# Altium schematic sheet 18 4G&5G.SchDoc
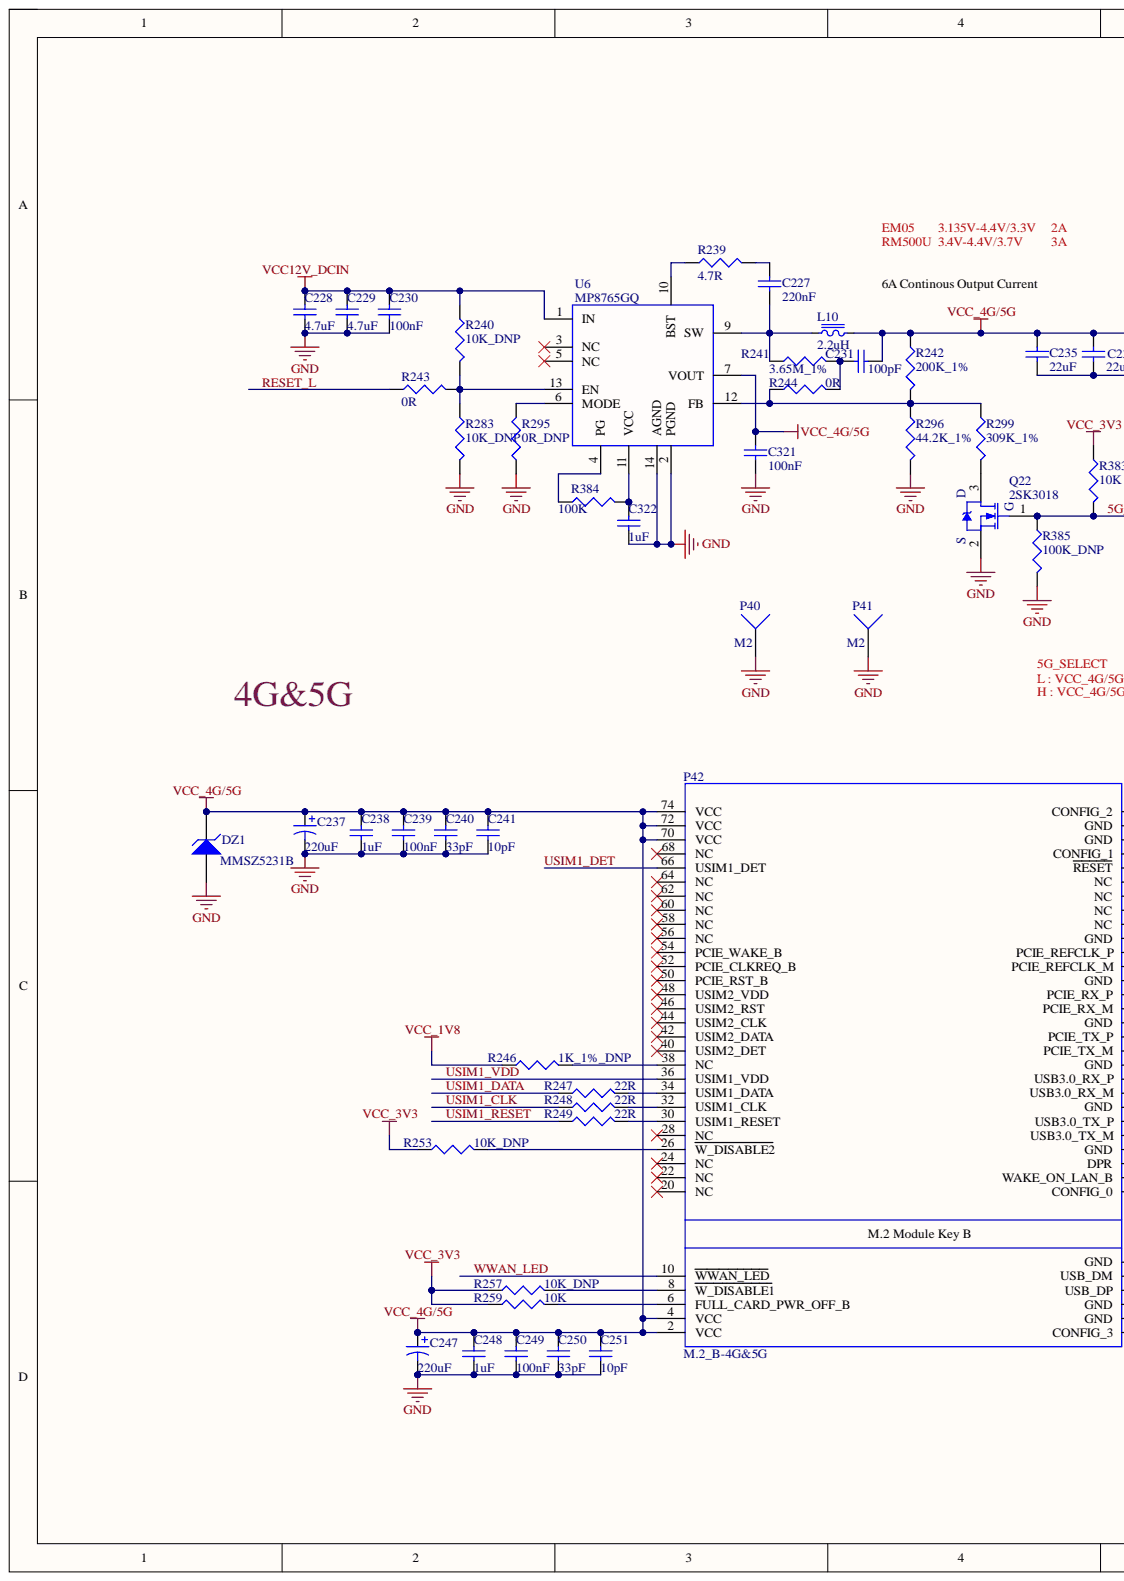
[diagram p0: 18 4G&5G.SchDoc, left]
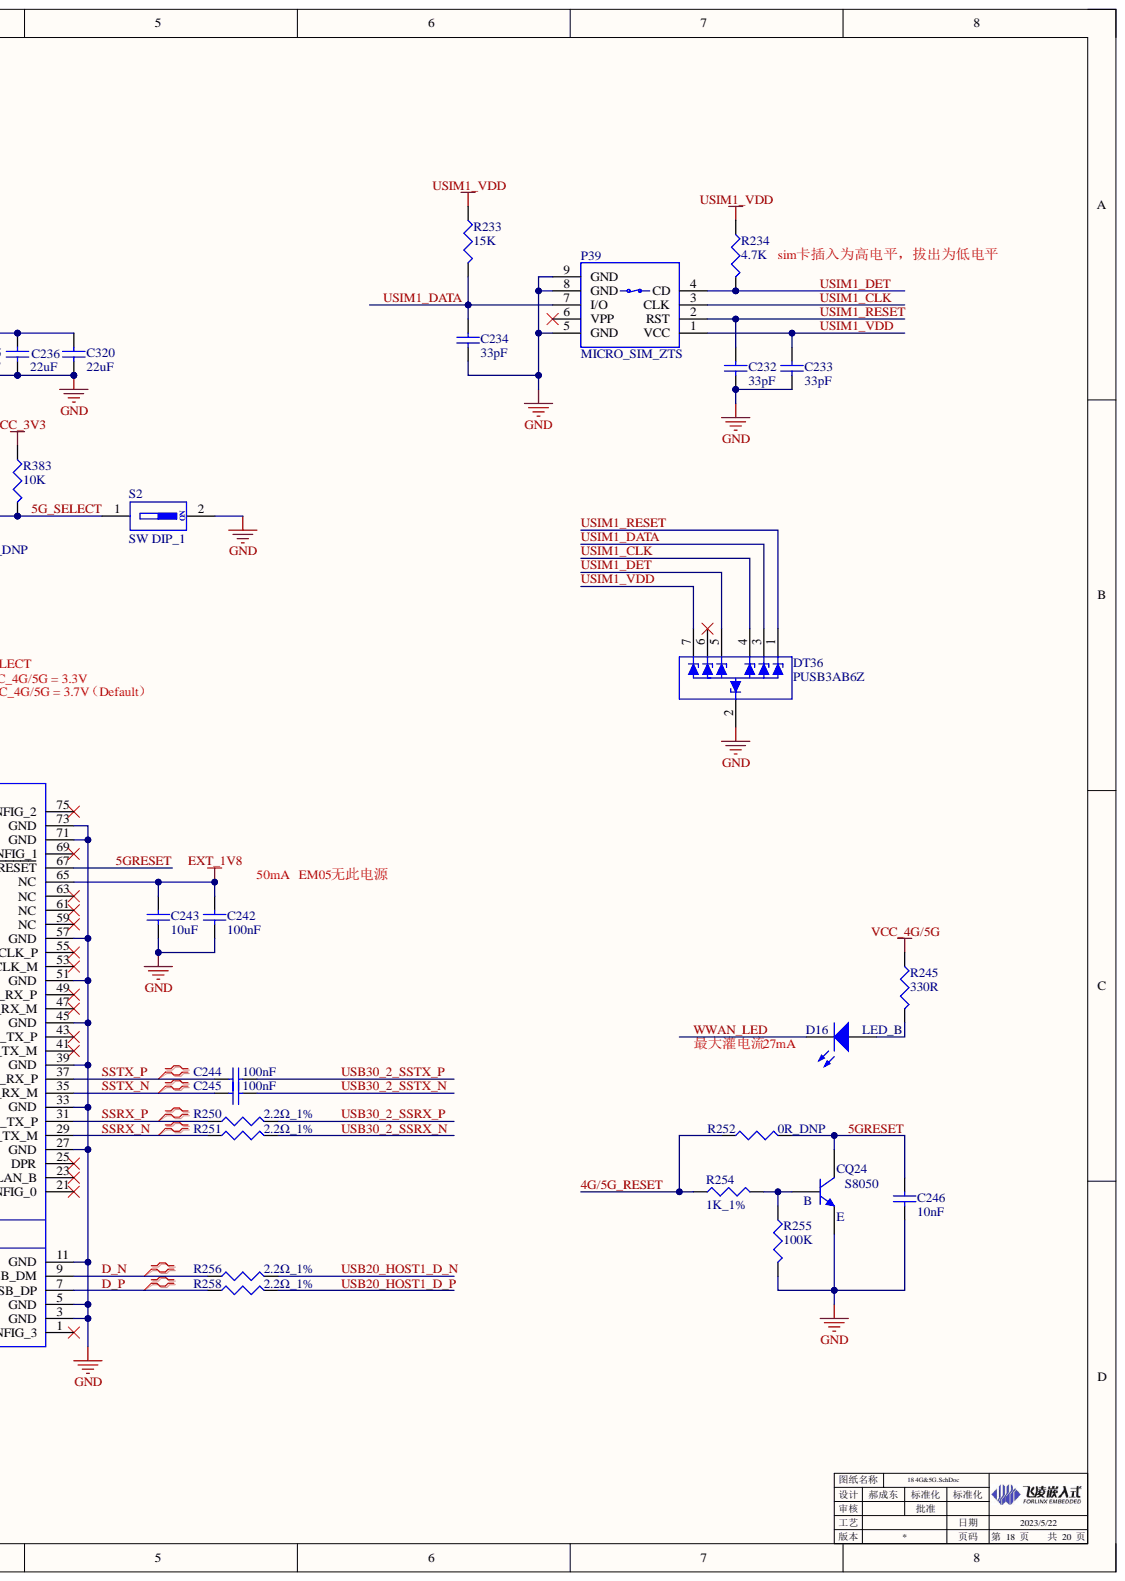
[diagram p1: 18 4G&5G.SchDoc, right]

## Parts
C227  220nF  [0402C]
C228  4.7uF  [0805C]
C229  4.7uF  [0805C]
C230  100nF  [0402C]
C231  100pF  [0402C]
C232  33pF  [0402C]
C233  33pF  [0402C]
C234  33pF  [0402C]
C235  22uF  [0805C]
C236  22uF  [0805C]
C237  220uF  [CAP SMT_6.3x7.7]
C238  1uF  [0402C]
C239  100nF  [0402C]
C240  33pF  [0402C]
C241  10pF  [0402C]
C242  100nF  [0402C]
C243  10uF  [0402C]
C244  100nF  [0402C]
C245  100nF  [0402C]
C246  10nF  [0402C]
C247  220uF  [CAP SMT_6.3x7.7]
C248  1uF  [0402C]
C249  100nF  [0402C]
C250  33pF  [0402C]
C251  10pF  [0402C]
C320  22uF  [0805C]
C321  100nF  [0402C]
C322  1uF  [0402C]
D16  LED_B  [0603LED_B]
DT36  PUSB3AB6Z  [DFN2111-7]
DZ1  MMSZ5231B  [SOD_123]
L10  2.2uH  [WPN4020H]
P39  MICRO_SIM_ZTS  [MICRO_SIM_ZTS]
P40  M2  [HOLE3.6-5.6]
P41  M2  [HOLE3.6-5.6]
P42  M.2_B-4G&5G  [M.2_B_4G5G]
Q22  2SK3018  [SOT323]
Q24  S8050  [SOT_233_N]
R233  15K  [0402R]
R234  4.7K  [0402R]
R239  4.7R  [0603R]
R240  10K_DNP  [0402R]
R241  3.65M_1%  [0603R]
R242  200K_1%  [0402R]
R243  0R  [0402R]
R244  0R  [0402R]
R245  330R  [0402R]
R246  1K_1%_DNP  [0402R]
R247  22R  [0402R]
R248  22R  [0402R]
R249  22R  [0402R]
R250  2.2Ω_1%  [0402R]
R251  2.2Ω_1%  [0402R]
R252  0R_DNP  [0402R]
R253  10K_DNP  [0402R]
R254  1K_1%  [0402R]
R255  100K  [0402R]
R256  2.2Ω_1%  [0402R]
R257  10K_DNP  [0402R]
R258  2.2Ω_1%  [0402R]
R259  10K  [0402R]
R283  10K_DNP  [0402R]
R295  0R_DNP  [0402R]
R296  44.2K_1%  [0402R]
R299  309K_1%  [0402R]
R383  10K  [0402R]
R384  100K  [0402R]
R385  100K_DNP  [0402R]
S2  SW DIP_1  [SWITCH_1P_DIP]
U6  MP8765GQ  [QFN16P_MP8765]

## Nets
EXT_1V8: C242.2 C243.2 P42.65(NC)
GND: C228.1 C229.1 C230.1 C232.1 C233.1 C234.1 C235.1 C236.1 C237.2 C238.1 C239.1 C240.1 C241.1 C242.1 C243.1 C246.1 C247.2 C248.1 C249.1 C250.1 C251.1 C320.1 C321.1 C322.1 DT36.2 DZ1.1 P39.5(GND) P39.8(GND) P39.9(GND) P40.1 P41.1 P42.3(GND) P42.5(GND) P42.11(GND) P42.27(GND) P42.33(GND) P42.39(GND) P42.45(GND) P42.51(GND) P42.57(GND) P42.71(GND) P42.73(GND) Q22.2 Q24.2 R255.2 R283.2 R295.1 R296.2 R385.2 S2.2 U6.2(PGND) U6.14(AGND)
VCC12V_DCIN: C228.2 C229.2 C230.2 R240.1 U6.1(IN)
VCC_1V8: R246.1
VCC_3V3: R253.1 R257.1 R259.1 R383.1
VCC_4G/5G: C231.2 C235.2 C236.2 C237.1 C238.2 C239.2 C240.2 C241.2 C247.1 C248.2 C249.2 C250.2 C251.2 C320.2 C321.2 DZ1.2 L10.2 P42.2(VCC) P42.4(VCC) P42.70(VCC) P42.72(VCC) P42.74(VCC) R242.1 R245.1 U6.7(VOUT)
4G/5G_RESET: R252.1 R254.1
5G_SELECT: Q22.1 R383.2 R385.1 S2.1
5GRESET: C246.2 P42.67(RESET) Q24.3 R252.2
D_N: P42.9(USB_DM) R256.1
D_P: P42.7(USB_DP) R258.1
RESET_L: R243.1
SSRX_N: P42.29(USB3.0_TX_M) R251.1
SSRX_P: P42.31(USB3.0_TX_P) R250.1
SSTX_N: C245.1 P42.35(USB3.0_RX_M)
SSTX_P: C244.1 P42.37(USB3.0_RX_P)
USB20_HOST1_D_N: R256.2
USB20_HOST1_D_P: R258.2
USB30_2_SSRX_N: R251.2
USB30_2_SSRX_P: R250.2
USB30_2_SSTX_N: C245.2
USB30_2_SSTX_P: C244.2
USIM1_CLK: DT36.4 P39.3(CLK) R248.1
USIM1_DATA: C234.2 DT36.3 P39.7(I/O) R233.2 R247.1
USIM1_DET: DT36.5 P39.4(CD) P42.66(USIM1_DET) R234.2
USIM1_RESET: C232.2 DT36.1 P39.2(RST) R249.1
USIM1_VDD: C233.2 DT36.7 P39.1(VCC) P42.36(USIM1_VDD) R233.1 R234.1
WWAN_LED: D16.2 P42.10(WWAN_LED)
NetC227_1: C227.1 L10.1 R241.1 U6.9(SW)
NetC227_2: C227.2 R239.2
NetC231_1: C231.1 R241.2 R244.2
NetC322_2: C322.2 R384.2 U6.11(VCC)
NetD16_1: D16.1 R245.2
NetP42_6: P42.6(FULL_CARD_PWR_OFF_B) R259.2
NetP42_8: P42.8(W_DISABLE1) R257.2
NetP42_26: P42.26(W_DISABLE2) R253.2
NetP42_30: P42.30(USIM1_RESET) R249.2
NetP42_32: P42.32(USIM1_CLK) R248.2
NetP42_34: P42.34(USIM1_DATA) R247.2
NetP42_38: P42.38(NC) R246.2
NetQ22_3: Q22.3 R299.2
NetQ24_1: Q24.1 R254.2 R255.1
NetR239_1: R239.1 U6.10(BST)
NetR240_2: R240.2 R243.2 R283.1 U6.13(EN)
NetR242_2: R242.2 R244.1 R296.1 R299.1 U6.12(FB)
NetR295_2: R295.2 U6.6(MODE)
NetR384_1: R384.1 U6.4(PG)

Not connected: DT36 6; P39 6(VPP); P42 1(CONFIG_3) 20(NC) 21(CONFIG_0) 22(NC) 23(WAKE_ON_LAN_B) 24(NC) 25(DPR) 28(NC) 40(USIM2_DET) 41(PCIE_TX_M) 42(USIM2_DATA) 43(PCIE_TX_P) 44(USIM2_CLK) 46(USIM2_RST) 47(PCIE_RX_M) 48(USIM2_VDD) 49(PCIE_RX_P) 50(PCIE_RST_B) 52(PCIE_CLKREQ_B) 53(PCIE_REFCLK_M) 54(PCIE_WAKE_B) 55(PCIE_REFCLK_P) 56(NC) 58(NC) 59(NC) 60(NC) 61(NC) 62(NC) 63(NC) 64(NC) 68(NC) 69(CONFIG_1) 75(CONFIG_2); U6 3(NC) 5(NC)

## Library data of the parts
C227 = 1110202042: Database Table Name: VALID$; 存货名称: 贴装叠层陶瓷电容; 规格型号: 0402,220nF,25V,±10％,X5R; Component Kind: Standard; LatestRevisionDate: 17-Jul-2002; LatestRevisionNote: Re-released for DXP Platform.; Library Name: Database_AD Library.DbLib; PackageDescription: Radial Cap, Thru-Hole; 2 Leads; 0.3 in Pin Spacing; PackageReference: RAD-0.3; Published: 8-Jun-2000; Signal Integrity: Cap; Simulation: CAP; Value: 220nF; 存货编码: 1110202042; Publisher: Altium Limited
C228 C229 = 1110204006: Database Table Name: VALID$; 存货名称: 贴装叠层陶瓷电容; 序号: 738; 规格型号: 0805,4.7uF,50V,±10％,X5R; Component Kind: Standard; LatestRevisionDate: 17-Jul-2002; LatestRevisionNote: Re-released for DXP Platform.; Library Name: Database_AD Library.DbLib; PackageDescription: Radial Cap, Thru-Hole; 2 Leads; 0.3 in Pin Spacing; PackageReference: RAD-0.3; Published: 8-Jun-2000; Signal Integrity: Cap; Simulation: CAP; Value: 4.7uF; 存货编码: 1110204006; Publisher: Altium Limited
C230 C239 C249 C321 = 1110202039: Database Table Name: VALID$; 存货名称: 贴装叠层陶瓷电容; 序号: 626; 规格型号: 0402,100nF,25V,±10％,X5R; Component Kind: Standard; LatestRevisionDate: 17-Jul-2002; LatestRevisionNote: Re-released for DXP Platform.; Library Name: Database_AD Library.DbLib; PackageDescription: Radial Cap, Thru-Hole; 2 Leads; 0.3 in Pin Spacing; PackageReference: RAD-0.3; Published: 8-Jun-2000; Signal Integrity: Cap; Simulation: CAP; Value: 100nF; 存货编码: 1110202039; Publisher: Altium Limited
C231 = 1110202016: Database Table Name: VALID$; 存货名称: 贴装叠层陶瓷电容; 序号: 587; 规格型号: 0402,100pF,50V,±5％,NPO; Component Kind: Standard; LatestRevisionDate: 17-Jul-2002; LatestRevisionNote: Re-released for DXP Platform.; Library Name: Database_AD Library.DbLib; PackageDescription: Radial Cap, Thru-Hole; 2 Leads; 0.3 in Pin Spacing; PackageReference: RAD-0.3; Published: 8-Jun-2000; Signal Integrity: Cap; Simulation: CAP; Value: 100pF; 存货编码: 1110202016; Publisher: Altium Limited
C232-C234 C240 C250 = 1110202020: Database Table Name: VALID$; 存货名称: 贴装叠层陶瓷电容; 序号: 597; 规格型号: 0402,33pF,50V,±5％,NPO; Component Kind: Standard; LatestRevisionDate: 17-Jul-2002; LatestRevisionNote: Re-released for DXP Platform.; Library Name: Database_AD Library.DbLib; PackageDescription: Radial Cap, Thru-Hole; 2 Leads; 0.3 in Pin Spacing; PackageReference: RAD-0.3; Published: 8-Jun-2000; Signal Integrity: Cap; Simulation: CAP; Value: 33pF; 存货编码: 1110202020; Publisher: Altium Limited
C235 C236 C320 = 1110204014: Database Table Name: VALID$; 存货名称: 贴装叠层陶瓷电容; 安全库存: 2645; 序号: 751; 旧编码: 010203021; 旧规格型号: 0805 22uF 25V ±20％ X5R; 规格型号: 0805,22uF,25V,±20％,X5R; Component Kind: Standard; LatestRevisionDate: 17-Jul-2002; LatestRevisionNote: Re-released for DXP Platform.; Library Name: Database_AD Library.DbLib; PackageDescription: Radial Cap, Thru-Hole; 2 Leads; 0.3 in Pin Spacing; PackageReference: RAD-0.3; Published: 8-Jun-2000; Signal Integrity: Cap; Simulation: CAP; Value: 22uF; 存货编码: 1110204014; Publisher: Altium Limited
C237 C247 = 1110211005: Database Table Name: VALID$; 存货名称: 贴装铝电解电容; 存货编码: CS1C221M-CRE77; 序号: 861; 规格型号: 100uF,16V,6.3*5.9mm; Component Kind: Standard; LatestRevisionDate: 17-Jul-2002; LatestRevisionNote: Re-released for DXP Platform.; Library Name: Database_AD Library.DbLib; PackageDescription: Polarized Capacitor (Radial); 2 Leads; PackageReference: RB7.6-15; Published: 8-Jun-2000; Signal Integrity: Cap Pol; Simulation: CAP; Value: 220uF; Publisher: Altium Limited
C238 C248 C322 = 1110202021: Database Table Name: VALID$; 存货名称: 贴装叠层陶瓷电容; 序号: 600; 规格型号: 0402,1uF,16V,±10％,X5R; Component Kind: Standard; LatestRevisionDate: 17-Jul-2002; LatestRevisionNote: Re-released for DXP Platform.; Library Name: Database_AD Library.DbLib; PackageDescription: Radial Cap, Thru-Hole; 2 Leads; 0.3 in Pin Spacing; PackageReference: RAD-0.3; Published: 8-Jun-2000; Signal Integrity: Cap; Simulation: CAP; Value: 1uF; 存货编码: 1110202021; Publisher: Altium Limited
C241 C251 = 1110202010: Database Table Name: VALID$; 存货名称: 贴装叠层陶瓷电容; 序号: 581; 规格型号: 0402,10pF,50V,±5％,NPO; Component Kind: Standard; LatestRevisionDate: 17-Jul-2002; LatestRevisionNote: Re-released for DXP Platform.; Library Name: Database_AD Library.DbLib; PackageDescription: Radial Cap, Thru-Hole; 2 Leads; 0.3 in Pin Spacing; PackageReference: RAD-0.3; Published: 8-Jun-2000; Signal Integrity: Cap; Simulation: CAP; Value: 10pF; 存货编码: 1110202010; Publisher: Altium Limited
C242 C244 C245 = 1110202001: Database Table Name: VALID$; 主计量单位名称: 个; 存货名称: 贴装叠层陶瓷电容; 安全库存: 2580445; 序号: 570; 旧编码: 010201001; 旧规格型号: 0402 100nF 16V ±10％ X7R; 规格型号: 0402,100nF,16V,±10％,X7R; Component Kind: Standard; LatestRevisionDate: 17-Jul-2002; LatestRevisionNote: Re-released for DXP Platform.; Library Name: Database_AD Library.DbLib; PackageDescription: Radial Cap, Thru-Hole; 2 Leads; 0.3 in Pin Spacing; PackageReference: RAD-0.3; Published: 8-Jun-2000; Signal Integrity: Cap; Simulation: CAP; Value: 100nF; 存货编码: 1110202001; Publisher: Altium Limited
C243 = 1110202033: Database Table Name: VALID$; 存货名称: 贴装叠层陶瓷电容; 序号: 619; 规格型号: 0402,10uF,6.3V,±20％,X5R; Component Kind: Standard; LatestRevisionDate: 17-Jul-2002; LatestRevisionNote: Re-released for DXP Platform.; Library Name: Database_AD Library.DbLib; PackageDescription: Radial Cap, Thru-Hole; 2 Leads; 0.3 in Pin Spacing; PackageReference: RAD-0.3; Published: 8-Jun-2000; Signal Integrity: Cap; Simulation: CAP; Value: 10uF; 存货编码: 1110202033; Publisher: Altium Limited
C246 = 1110202014: Database Table Name: VALID$; 存货名称: 贴装叠层陶瓷电容; 序号: 585; 规格型号: 0402,10nF,50V,±10％,X7R; Component Kind: Standard; LatestRevisionDate: 17-Jul-2002; LatestRevisionNote: Re-released for DXP Platform.; Library Name: Database_AD Library.DbLib; PackageDescription: Radial Cap, Thru-Hole; 2 Leads; 0.3 in Pin Spacing; PackageReference: RAD-0.3; Published: 8-Jun-2000; Signal Integrity: Cap; Simulation: CAP; Value: 10nF; 存货编码: 1110202014; Publisher: Altium Limited
D16 = 1110504007: Database Table Name: VALID$; 存货名称: 贴装发光二极管; 序号: 2631; 规格型号: 0603,透明,蓝色发光,普通亮度; Component Kind: Standard; LatestRevisionDate: 17-Jul-2002; LatestRevisionNote: Re-released for DXP Platform.; Library Name: Database_AD Library.DbLib; PackageDescription: SM LED; 2 Flat Leads; PackageReference: 3.2X1.6X1.1; PackageVersion: Feb-2002; Published: 8-Jun-2000; Simulation: LED2; Value: LED_B; 存货编码: 1110504007; Publisher: Altium Limited
DT36 = 1110503004: Database Table Name: VALID$; 主计量单位名称: 只; 存货名称: TVS管; 安全库存: 198; 序号: 2555; 旧编码: 010601080; 旧规格型号: PUSB3AB6Z xson7; 规格型号: PUSB3AB6Z,xson7; Component Kind: Standard; Library Name: Database_AD Library.DbLib; Value: PUSB3AB6Z; 存货编码: 1110503004
DZ1 = 1110501012: Database Table Name: VALID$; 存货名称: 稳压二极管; 序号: 2494; 规格型号: MMSZ5231B,SOD-123; Component Kind: Standard; LatestRevisionDate: 17-Jul-2002; LatestRevisionNote: Re-released for DXP Platform.; Library Name: Database_AD Library.DbLib; PackageDescription: Diode, Thru-Hole; 2 Leads; 0.7 in Pin Spacing; PackageReference: DIODE-0.7; Published: 8-Jun-2000; Signal Integrity: D Zener; Simulation: ZENER; Value: MMSZ5231B; 存货编码: 1110501012; Publisher: Altium Limited
L10 = 1110301084: Database Table Name: VALID$; 存货名称: 绕线电感; 规格型号: 2.2uH,4*4*2mm,7.6A,平行焊盘,非屏蔽,WPN4020H2R2MT; Component Kind: Standard; LatestRevisionDate: 17-Jul-2002; LatestRevisionNote: Re-released for DXP Platform.; Library Name: Database_AD Library.DbLib; PackageDescription: Axial Device, Thru-Hole; 2 Leads; 0.9 in Pin Spacing; PackageReference: AXIAL-0.9; Published: 24-Mar-1999; Signal Integrity: Inductor Iron; Simulation: INDUCTOR; Value: 2.2uH; 存货编码: 1110301084; Publisher: Altium Limited
P39 = 1120102033: 自弹式，带卡检测; Database Table Name: VALID$; 存货名称: 贴装MicroSIM卡座; 描述栏信息: 替代1120102027; 规格型号: 7PIN-17.37*15.7*1.35mm,自弹式,带卡检测(质量优); Component Kind: Standard; Library Name: Database_AD Library.DbLib; Value: MICRO_SIM_ZTS; 存货编码: 1120102033
P40 P41 = 1150303063: Database Table Name: VALID$; 存货名称: 贴片螺母; 安全库存: 10; 规格型号: M2mm,长2.5mm; Component Kind: Standard; LatestRevisionDate: 17-Jul-2002; LatestRevisionNote: Re-released for DXP Platform.; Library Name: Database_AD Library.DbLib; Signal Integrity: Connector; Value: M2; 存货编码: 1150303063; Publisher: Altium Limited
P42 = M.2_B-4G&5G: Database Table Name: Other$; 存货名称: 贴装连接器; 存货编码: 1120101031; 旧编码: 11; 规格型号: M.2 KEY B,0.5mm,H4.2; Component Kind: Standard; Library Name: Database_AD Library.DbLib; Value: M.2_B-4G&5G
Q22 = 1110505016: Database Table Name: VALID$; Note: Pin 2 is electrically connected to pin 4.; 存货名称: 场效应管; 序号: 2672; 规格型号: 2SK3018,SOT-323,N沟道; Component Kind: Standard; DatasheetVersion: PD-9.814; LatestRevisionDate: 17-Jul-2002; LatestRevisionNote: Re-released for DXP Platform.; Library Name: Database_AD Library.DbLib; PackageDescription: TO, Thru-Hole, Vertical, Heatsink Mounted; 3 In-Line Leads; Pitch 2.54 mm; PackageReference: TO-220AB; PackageVersion: Feb-1997; Published: 8-Jun-2000; Simulation: IRF1010; VALUE: 2SK3018; 存货编码: 1110505016; Publisher: Altium Limited
Q24 = 1110502003: Database Table Name: VALID$; 主计量单位名称: 只; 存货名称: 三极管; 安全库存: 4597; 序号: 2564; 旧编码: 010602004; 旧规格型号: NPN S8050 SOT23-3; 规格型号: S8050,SOT23-3,NPN; Code_JEDEC: TO-226-AA; Component Kind: Standard; LatestRevisionDate: 17-Jul-2002; LatestRevisionNote: Re-released for DXP Platform.; Library Name: Database_AD Library.DbLib; PackageDescription: TO, Flat Index; 3 In-Line, Axial Leads; Body Dia. 4.8mm; Leads 0.55 x 0.51 mm (max); PackageReference: TO-226-AA; Published: 8-Jun-2000; Signal Integrity: NPN; Simulation: NPN; VALUE: S8050; 存货编码: 1110502003; Publisher: Altium Limited
R233 = 1110102026: Database Table Name: VALID$; 存货名称: 贴装电阻; 序号: 37; 规格型号: 0402,15K,±5％; Component Kind: Standard; Library Name: Database_AD Library.DbLib; Signal Integrity: Res; Simulation: RESISTOR; Value: 15K; 存货编码: 1110102026
R234 = 1110102003: Database Table Name: VALID$; 存货名称: 贴装电阻; 序号: 12; 规格型号: 0402,4.7K,±5％; Component Kind: Standard; Library Name: Database_AD Library.DbLib; Signal Integrity: Res; Simulation: RESISTOR; Value: 4.7K; 存货编码: 1110102003
R239 = 1110103060: Database Table Name: VALID$; 存货名称: 贴装电阻; 序号: 240; 规格型号: 0603,4.7Ω,±5％; Component Kind: Standard; Library Name: Database_AD Library.DbLib; Signal Integrity: Res; Simulation: RESISTOR; Value: 4.7R; 存货编码: 1110103060
R240 R253 R257 R259 R283 R383 = 1110102007: Database Table Name: VALID$; 存货名称: 贴装电阻; 序号: 17; 规格型号: 0402,10K,±5％; Component Kind: Standard; Library Name: Database_AD Library.DbLib; Signal Integrity: Res; Simulation: RESISTOR; 存货编码: 1110102007
  R240 R253 R257 R283: Value: 10K_DNP
  R259 R383: Value: 10K
R241 = 1110103139: Database Table Name: VALID$; 存货名称: 贴装电阻; 规格型号: 0603,3.65M,±1％; Component Kind: Standard; Library Name: Database_AD Library.DbLib; Signal Integrity: Res; Simulation: RESISTOR; Value: 3.65M_1%
R242 = 1110102049: Database Table Name: VALID$; 存货名称: 贴装电阻; 序号: 63; 规格型号: 0402,200K,±1％; Component Kind: Standard; Library Name: Database_AD Library.DbLib; Signal Integrity: Res; Simulation: RESISTOR; Value: 200K_1%; 存货编码: 1110102049
R243 R244 R252 R295 = 1110102001: Database Table Name: VALID$; 存货名称: 贴装电阻; 序号: 10; 规格型号: 0402,0Ω,±5％; Component Kind: Standard; Library Name: Database_AD Library.DbLib; Signal Integrity: Res; Simulation: RESISTOR; 存货编码: 1110102001
  R243 R244: Value: 0R
  R252 R295: Value: 0R_DNP
R245 = 1110102043: Database Table Name: VALID$; 存货名称: 贴装电阻; 序号: 56; 规格型号: 0402,330Ω,±5％; Component Kind: Standard; Library Name: Database_AD Library.DbLib; Signal Integrity: Res; Simulation: RESISTOR; Value: 330R; 存货编码: 1110102043
R246 R254 = 1110102011: Database Table Name: VALID$; 存货名称: 贴装电阻; 序号: 21; 规格型号: 0402,1K,±1％; Component Kind: Standard; Library Name: Database_AD Library.DbLib; Signal Integrity: Res; Simulation: RESISTOR; 存货编码: 1110102011
  R246: Value: 1K_1%_DNP
  R254: Value: 1K_1%
R247-R249 = 1110102028: Database Table Name: VALID$; 存货名称: 贴装电阻; 序号: 41; 规格型号: 0402,22Ω,±5％; Component Kind: Standard; Library Name: Database_AD Library.DbLib; Signal Integrity: Res; Simulation: RESISTOR; Value: 22R; 存货编码: 1110102028
R250 R251 R256 R258 = 1110102176: Database Table Name: VALID$; 存货名称: 贴装电阻; 规格型号: 0402,2.2Ω,±1％; Component Kind: Standard; Library Name: Database_AD Library.DbLib; Signal Integrity: Res; Simulation: RESISTOR; Value: 2.2Ω_1%; 存货编码: 1110102176
R255 R384 R385 = 1110102004: Database Table Name: VALID$; 存货名称: 贴装电阻; 序号: 14; 规格型号: 0402,100K,±5％; Component Kind: Standard; Library Name: Database_AD Library.DbLib; Signal Integrity: Res; Simulation: RESISTOR; 存货编码: 1110102004
  R255 R384: Value: 100K
  R385: Value: 100K_DNP
R296 = 1110102127: Database Table Name: VALID$; 存货名称: 贴装电阻; 序号: 164; 规格型号: 0402,44.2K,±1％; Component Kind: Standard; Library Name: Database_AD Library.DbLib; Signal Integrity: Res; Simulation: RESISTOR; Value: 44.2K_1%; 存货编码: 1110102127
R299 = 1110102133: Database Table Name: VALID$; 存货名称: 贴装电阻; 规格型号: 0402,309K, ±1％; Component Kind: Standard; Library Name: Database_AD Library.DbLib; Signal Integrity: Res; Simulation: RESISTOR; Value: 309K_1%; 存货编码: 1110102133
S2 = 1120204009: Database Table Name: VALID$; 存货名称: 插装拨码开关; 序号: 3491; 规格型号: 1位,2P,红色; Component Kind: Standard; LatestRevisionDate: 17-Jul-2002; LatestRevisionNote: Re-released for DXP Platform.; Library Name: Database_AD Library.DbLib; PackageReference: DIP-4; Published: 24-Mar-1999; Simulation: dpsw2; Value: SW DIP_1; 存货编码: 1120204009; Publisher: Altium Limited
U6 = 1110404112: Database Table Name: VALID$; 存货名称: 电源; 安全库存: 105; 旧规格型号: MP8765GQ,QFN16; Component Kind: Standard; Library Name: Database_AD Library.DbLib; Value: MP8765GQ; 存货编码: 1110404112; 规格型号: MP8765GQ,QFN16
